# Altium schematic sheet SWITCHING.SchDoc
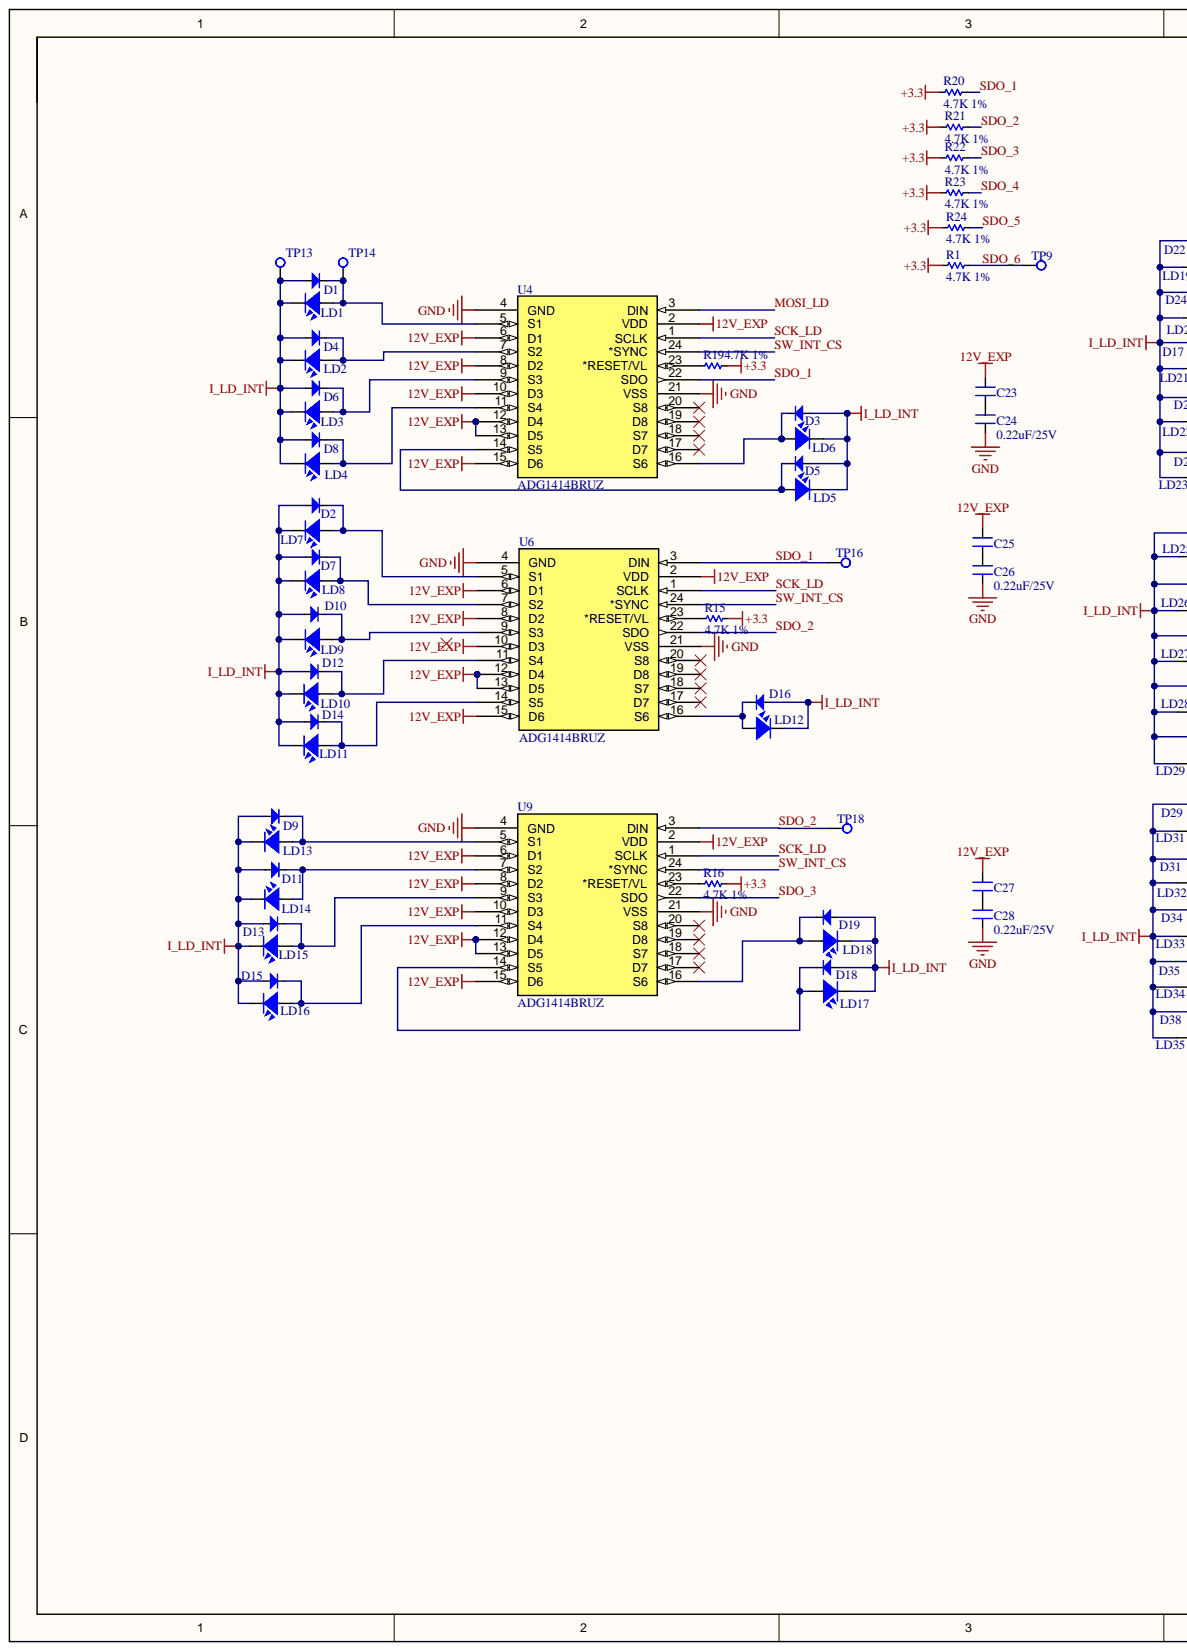
[diagram p0: SWITCHING.SchDoc, left]
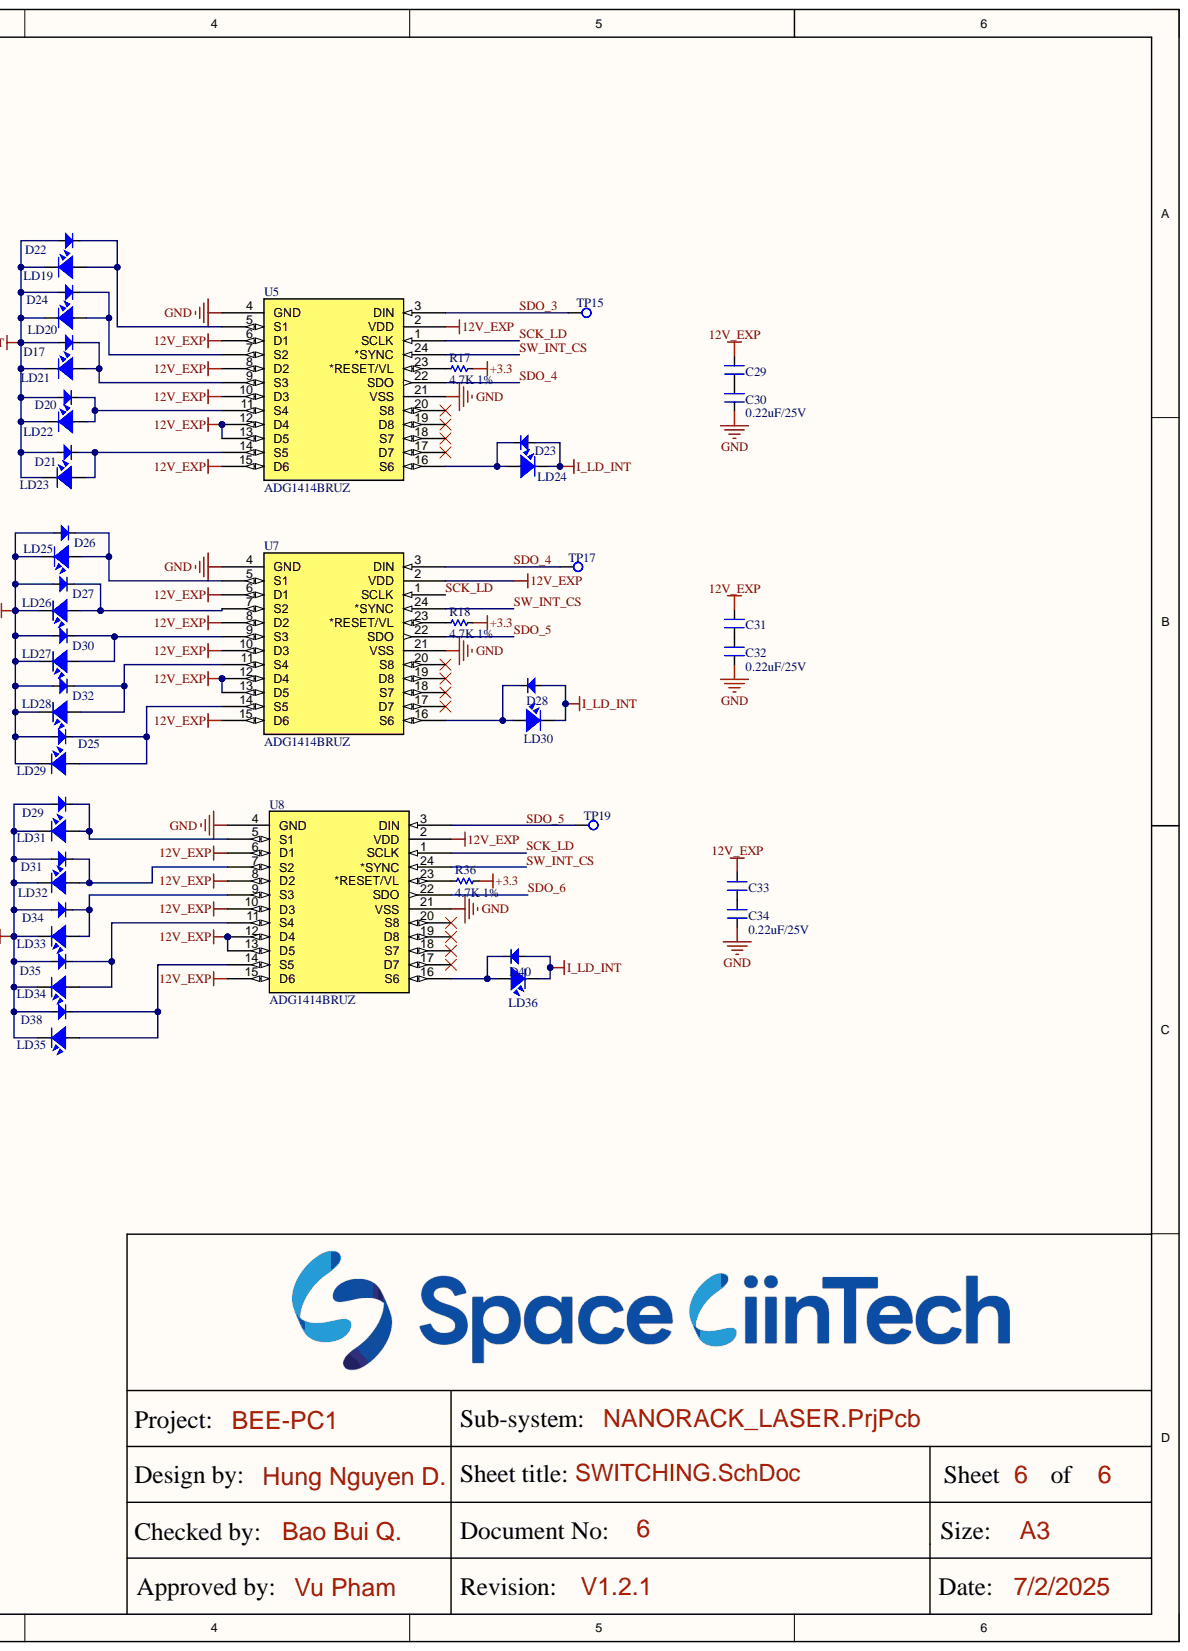
[diagram p1: SWITCHING.SchDoc, right]

## Parts
C23  0.22uF/25V  [SM-0603]
C24  0.22uF/25V  [SM-0603]
C25  0.22uF/25V  [SM-0603]
C26  0.22uF/25V  [SM-0603]
C27  0.22uF/25V  [SM-0603]
C28  0.22uF/25V  [SM-0603]
C29  0.22uF/25V  [SM-0603]
C30  0.22uF/25V  [SM-0603]
C31  0.22uF/25V  [SM-0603]
C32  0.22uF/25V  [SM-0603]
C33  0.22uF/25V  [SM-0603]
C34  0.22uF/25V  [SM-0603]
D1  1N4148W-TP  [SOD23]  (value not drawn)
D2  1N4148W-TP  [SOD23]  (value not drawn)
D3  1N4148W-TP  [SOD23]  (value not drawn)
D4  1N4148W-TP  [SOD23]  (value not drawn)
D5  1N4148W-TP  [SOD23]  (value not drawn)
D6  1N4148W-TP  [SOD23]  (value not drawn)
D7  1N4148W-TP  [SOD23]  (value not drawn)
D8  1N4148W-TP  [SOD23]  (value not drawn)
D9  1N4148W-TP  [SOD23]  (value not drawn)
D10  1N4148W-TP  [SOD23]  (value not drawn)
D11  1N4148W-TP  [SOD23]  (value not drawn)
D12  1N4148W-TP  [SOD23]  (value not drawn)
D13  1N4148W-TP  [SOD23]  (value not drawn)
D14  1N4148W-TP  [SOD23]  (value not drawn)
D15  1N4148W-TP  [SOD23]  (value not drawn)
D16  1N4148W-TP  [SOD23]  (value not drawn)
D17  1N4148W-TP  [SOD23]  (value not drawn)
D18  1N4148W-TP  [SOD23]  (value not drawn)
D19  1N4148W-TP  [SOD23]  (value not drawn)
D20  1N4148W-TP  [SOD23]  (value not drawn)
D21  1N4148W-TP  [SOD23]  (value not drawn)
D22  1N4148W-TP  [SOD23]  (value not drawn)
D23  1N4148W-TP  [SOD23]  (value not drawn)
D24  1N4148W-TP  [SOD23]  (value not drawn)
D25  1N4148W-TP  [SOD23]  (value not drawn)
D26  1N4148W-TP  [SOD23]  (value not drawn)
D27  1N4148W-TP  [SOD23]  (value not drawn)
D28  1N4148W-TP  [SOD23]  (value not drawn)
D29  1N4148W-TP  [SOD23]  (value not drawn)
D30  1N4148W-TP  [SOD23]  (value not drawn)
D31  1N4148W-TP  [SOD23]  (value not drawn)
D32  1N4148W-TP  [SOD23]  (value not drawn)
D34  1N4148W-TP  [SOD23]  (value not drawn)
D35  1N4148W-TP  [SOD23]  (value not drawn)
D38  1N4148W-TP  [SOD23]  (value not drawn)
D40  1N4148W-TP  [SOD23]  (value not drawn)
LD1  LaserDiode  [LASER_SOCKET]  (value not drawn)
LD2  LaserDiode  [LASER_SOCKET]  (value not drawn)
LD3  LaserDiode  [LASER_SOCKET]  (value not drawn)
LD4  LaserDiode  [LASER_SOCKET]  (value not drawn)
LD5  LaserDiode  [LASER_SOCKET]  (value not drawn)
LD6  LaserDiode  [LASER_SOCKET]  (value not drawn)
LD7  LaserDiode  [LASER_SOCKET]  (value not drawn)
LD8  LaserDiode  [LASER_SOCKET]  (value not drawn)
LD9  LaserDiode  [LASER_SOCKET]  (value not drawn)
LD10  LaserDiode  [LASER_SOCKET]  (value not drawn)
LD11  LaserDiode  [LASER_SOCKET]  (value not drawn)
LD12  LaserDiode  [LASER_SOCKET]  (value not drawn)
LD13  LaserDiode  [LASER_SOCKET]  (value not drawn)
LD14  LaserDiode  [LASER_SOCKET]  (value not drawn)
LD15  LaserDiode  [LASER_SOCKET]  (value not drawn)
LD16  LaserDiode  [LASER_SOCKET]  (value not drawn)
LD17  LaserDiode  [LASER_SOCKET]  (value not drawn)
LD18  LaserDiode  [LASER_SOCKET]  (value not drawn)
LD19  LaserDiode  [LASER_SOCKET]  (value not drawn)
LD20  LaserDiode  [LASER_SOCKET]  (value not drawn)
LD21  LaserDiode  [LASER_SOCKET]  (value not drawn)
LD22  LaserDiode  [LASER_SOCKET]  (value not drawn)
LD23  LaserDiode  [LASER_SOCKET]  (value not drawn)
LD24  LaserDiode  [LASER_SOCKET]  (value not drawn)
LD25  LaserDiode  [LASER_SOCKET]  (value not drawn)
LD26  LaserDiode  [LASER_SOCKET]  (value not drawn)
LD27  LaserDiode  [LASER_SOCKET]  (value not drawn)
LD28  LaserDiode  [LASER_SOCKET]  (value not drawn)
LD29  LaserDiode  [LASER_SOCKET]  (value not drawn)
LD30  LaserDiode  [LASER_SOCKET]  (value not drawn)
LD31  LaserDiode  [LASER_SOCKET]  (value not drawn)
LD32  LaserDiode  [LASER_SOCKET]  (value not drawn)
LD33  LaserDiode  [LASER_SOCKET]  (value not drawn)
LD34  LaserDiode  [LASER_SOCKET]  (value not drawn)
LD35  LaserDiode  [LASER_SOCKET]  (value not drawn)
LD36  LaserDiode  [LASER_SOCKET]  (value not drawn)
R1  4.7K 1%  [RES-SMD-0603]
R15  4.7K 1%  [RES-SMD-0603]
R16  4.7K 1%  [RES-SMD-0603]
R17  4.7K 1%  [RES-SMD-0603]
R18  4.7K 1%  [RES-SMD-0603]
R19  4.7K 1%  [RES-SMD-0603]
R20  4.7K 1%  [RES-SMD-0603]
R21  4.7K 1%  [RES-SMD-0603]
R22  4.7K 1%  [RES-SMD-0603]
R23  4.7K 1%  [RES-SMD-0603]
R24  4.7K 1%  [RES-SMD-0603]
R36  4.7K 1%  [RES-SMD-0603]
TP9  Test_Point  [TESTPOINT]  (value not drawn)
TP13  Test_Point  [TESTPOINT]  (value not drawn)
TP14  Test_Point  [TESTPOINT]  (value not drawn)
TP15  Test_Point  [TESTPOINT]  (value not drawn)
TP16  Test_Point  [TESTPOINT]  (value not drawn)
TP17  Test_Point  [TESTPOINT]  (value not drawn)
TP18  Test_Point  [TESTPOINT]  (value not drawn)
TP19  Test_Point  [TESTPOINT]  (value not drawn)
U4  ADG1414BRUZ  [TSSOP24]
U5  ADG1414BRUZ  [TSSOP24]
U6  ADG1414BRUZ  [TSSOP24]
U7  ADG1414BRUZ  [TSSOP24]
U8  ADG1414BRUZ  [TSSOP24]
U9  ADG1414BRUZ  [TSSOP24]

## Nets
12V_EXP: C23.2 C25.2 C27.2 C29.2 C31.2 C33.2 U4.2(VDD) U4.6(D1) U4.8(D2) U4.10(D3) U4.12(D4) U4.13(D5) U4.15(D6) U5.2(VDD) U5.6(D1) U5.8(D2) U5.10(D3) U5.12(D4) U5.13(D5) U5.15(D6) U6.2(VDD) U6.6(D1) U6.8(D2) U6.10(D3) U6.12(D4) U6.13(D5) U6.15(D6) U7.2(VDD) U7.6(D1) U7.8(D2) U7.10(D3) U7.12(D4) U7.13(D5) U7.15(D6) U8.2(VDD) U8.6(D1) U8.8(D2) U8.10(D3) U8.12(D4) U8.13(D5) U8.15(D6) U9.2(VDD) U9.6(D1) U9.8(D2) U9.10(D3) U9.12(D4) U9.13(D5) U9.15(D6)
+3.3: R1.2 R15.2 R16.2 R17.2 R18.2 R19.2 R20.2 R21.2 R22.2 R23.2 R24.2 R36.2
GND: C24.1 C26.1 C28.1 C30.1 C32.1 C34.1 U4.4(GND) U4.21(VSS) U5.4(GND) U5.21(VSS) U6.4(GND) U6.21(VSS) U7.4(GND) U7.21(VSS) U8.4(GND) U8.21(VSS) U9.4(GND) U9.21(VSS)
I_LD_INT: D1.1 D2.1 D3.1 D4.1 D5.1 D6.1 D7.1 D8.1 D9.1 D10.1 D11.1 D12.1 D13.1 D14.1 D15.1 D16.1 D17.1 D18.1 D19.1 D20.1 D21.1 D22.1 D23.1 D24.1 D25.1 D26.1 D27.1 D28.1 D29.1 D30.1 D31.1 D32.1 D34.1 D35.1 D38.1 D40.1 LD1.3 LD2.3 LD3.3 LD4.3 LD5.3 LD6.3 LD7.3 LD8.3 LD9.3 LD10.3 LD11.3 LD12.3 LD13.3 LD14.3 LD15.3 LD16.3 LD17.3 LD18.3 LD19.3 LD20.3 LD21.3 LD22.3 LD23.3 LD24.3 LD25.3 LD26.3 LD27.3 LD28.3 LD29.3 LD30.3 LD31.3 LD32.3 LD33.3 LD34.3 LD35.3 LD36.3 TP13.1
MOSI_LD: U4.3(DIN)
SCK_LD: U4.1(SCLK) U5.1(SCLK) U6.1(SCLK) U7.1(SCLK) U8.1(SCLK) U9.1(SCLK)
SDO_1: R20.1 TP16.1 U4.22(SDO) U6.3(DIN)
SDO_2: R21.1 TP18.1 U6.22(SDO) U9.3(DIN)
SDO_3: R22.1 TP15.1 U5.3(DIN) U9.22(SDO)
SDO_4: R23.1 TP17.1 U5.22(SDO) U7.3(DIN)
SDO_5: R24.1 TP19.1 U7.22(SDO) U8.3(DIN)
SDO_6: R1.1 TP9.1 U8.22(SDO)
SW_INT_CS: U4.24(*SYNC) U5.24(*SYNC) U6.24(*SYNC) U7.24(*SYNC) U8.24(*SYNC) U9.24(*SYNC)
NetC23_1: C23.1 C24.2
NetC25_1: C25.1 C26.2
NetC27_1: C27.1 C28.2
NetC29_1: C29.1 C30.2
NetC31_1: C31.1 C32.2
NetC33_1: C33.1 C34.2
NetD1_2: D1.2 LD1.1 TP14.1 U4.5(S1)
NetD2_2: D2.2 LD7.1 U6.5(S1)
NetD3_2: D3.2 LD6.1 U4.16(S6)
NetD4_2: D4.2 LD2.1 U4.7(S2)
NetD5_2: D5.2 LD5.1 U4.14(S5)
NetD6_2: D6.2 LD3.1 U4.9(S3)
NetD7_2: D7.2 LD8.1 U6.7(S2)
NetD8_2: D8.2 LD4.1 U4.11(S4)
NetD9_2: D9.2 LD13.1 U9.5(S1)
NetD10_2: D10.2 LD9.1 U6.9(S3)
NetD11_2: D11.2 LD14.1 U9.7(S2)
NetD12_2: D12.2 LD10.1 U6.11(S4)
NetD13_2: D13.2 LD15.1 U9.9(S3)
NetD14_2: D14.2 LD11.1 U6.14(S5)
NetD15_2: D15.2 LD16.1 U9.11(S4)
NetD16_2: D16.2 LD12.1 U6.16(S6)
NetD17_2: D17.2 LD21.1 U5.9(S3)
NetD18_2: D18.2 LD17.1 U9.14(S5)
NetD19_2: D19.2 LD18.1 U9.16(S6)
NetD20_2: D20.2 LD22.1 U5.11(S4)
NetD21_2: D21.2 LD23.1 U5.14(S5)
NetD22_2: D22.2 LD19.1 U5.5(S1)
NetD23_2: D23.2 LD24.1 U5.16(S6)
NetD24_2: D24.2 LD20.1 U5.7(S2)
NetD25_2: D25.2 LD29.1 U7.14(S5)
NetD26_2: D26.2 LD25.1 U7.5(S1)
NetD27_2: D27.2 LD26.1 U7.7(S2)
NetD28_2: D28.2 LD30.1 U7.16(S6)
NetD29_2: D29.2 LD31.1 U8.5(S1)
NetD30_2: D30.2 LD27.1 U7.9(S3)
NetD31_2: D31.2 LD32.1 U8.7(S2)
NetD32_2: D32.2 LD28.1 U7.11(S4)
NetD34_2: D34.2 LD33.1 U8.9(S3)
NetD35_2: D35.2 LD34.1 U8.11(S4)
NetD38_2: D38.2 LD35.1 U8.14(S5)
NetD40_2: D40.2 LD36.1 U8.16(S6)
NetR15_1: R15.1 U6.23(*RESET/VL)
NetR16_1: R16.1 U9.23(*RESET/VL)
NetR17_1: R17.1 U5.23(*RESET/VL)
NetR18_1: R18.1 U7.23(*RESET/VL)
NetR19_1: R19.1 U4.23(*RESET/VL)
NetR36_1: R36.1 U8.23(*RESET/VL)

Not connected: U4 17(D7) 18(S7) 19(D8) 20(S8); U5 17(D7) 18(S7) 19(D8) 20(S8); U6 17(D7) 18(S7) 19(D8) 20(S8); U7 17(D7) 18(S7) 19(D8) 20(S8); U8 17(D7) 18(S7) 19(D8) 20(S8); U9 17(D7) 18(S7) 19(D8) 20(S8)

## Library data of the parts
C23-C34 = CM: 0603; Value: 103; Component Kind: Standard; Library Name: Capacitors.SchLib
D1-D32 D34 D35 D38 D40 = Diode SMD: DIODE GEN PURP 100V 150MA SOD123; Code - JEDEC: DO-214-AB; Value: 1N5007; Component Kind: Standard; Library Name: Library.SchLib; Published: 8-Jun-2000; Revision: July-2002: Re-released for DXP Platform.; Publisher: Altium Limited
LD1-LD36 = LD: Component Kind: Standard; Library Name: Library_HUNG.SchLib
R1 R15-R24 R36 = Res-SMD: 0603; Manufacturer: Generic Components; Class: Passive; Component Kind: Standard; Library Name: Library.SchLib; Published: 08/06/2000; Publisher: Altium Hobart Technology Centre
U4-U9 = ADG1414BRUZ: IC SW SPST-NOX8 11.5OHM 24TSSOP; Component Kind: Standard; Library Name: Library_HUNG.SchLib
TP9 TP13-TP19 = Test_Point: Component Kind: Standard; Library Name: Library_HUNG.SchLib
